# Altium schematic sheet PWR_Manage.SchDoc
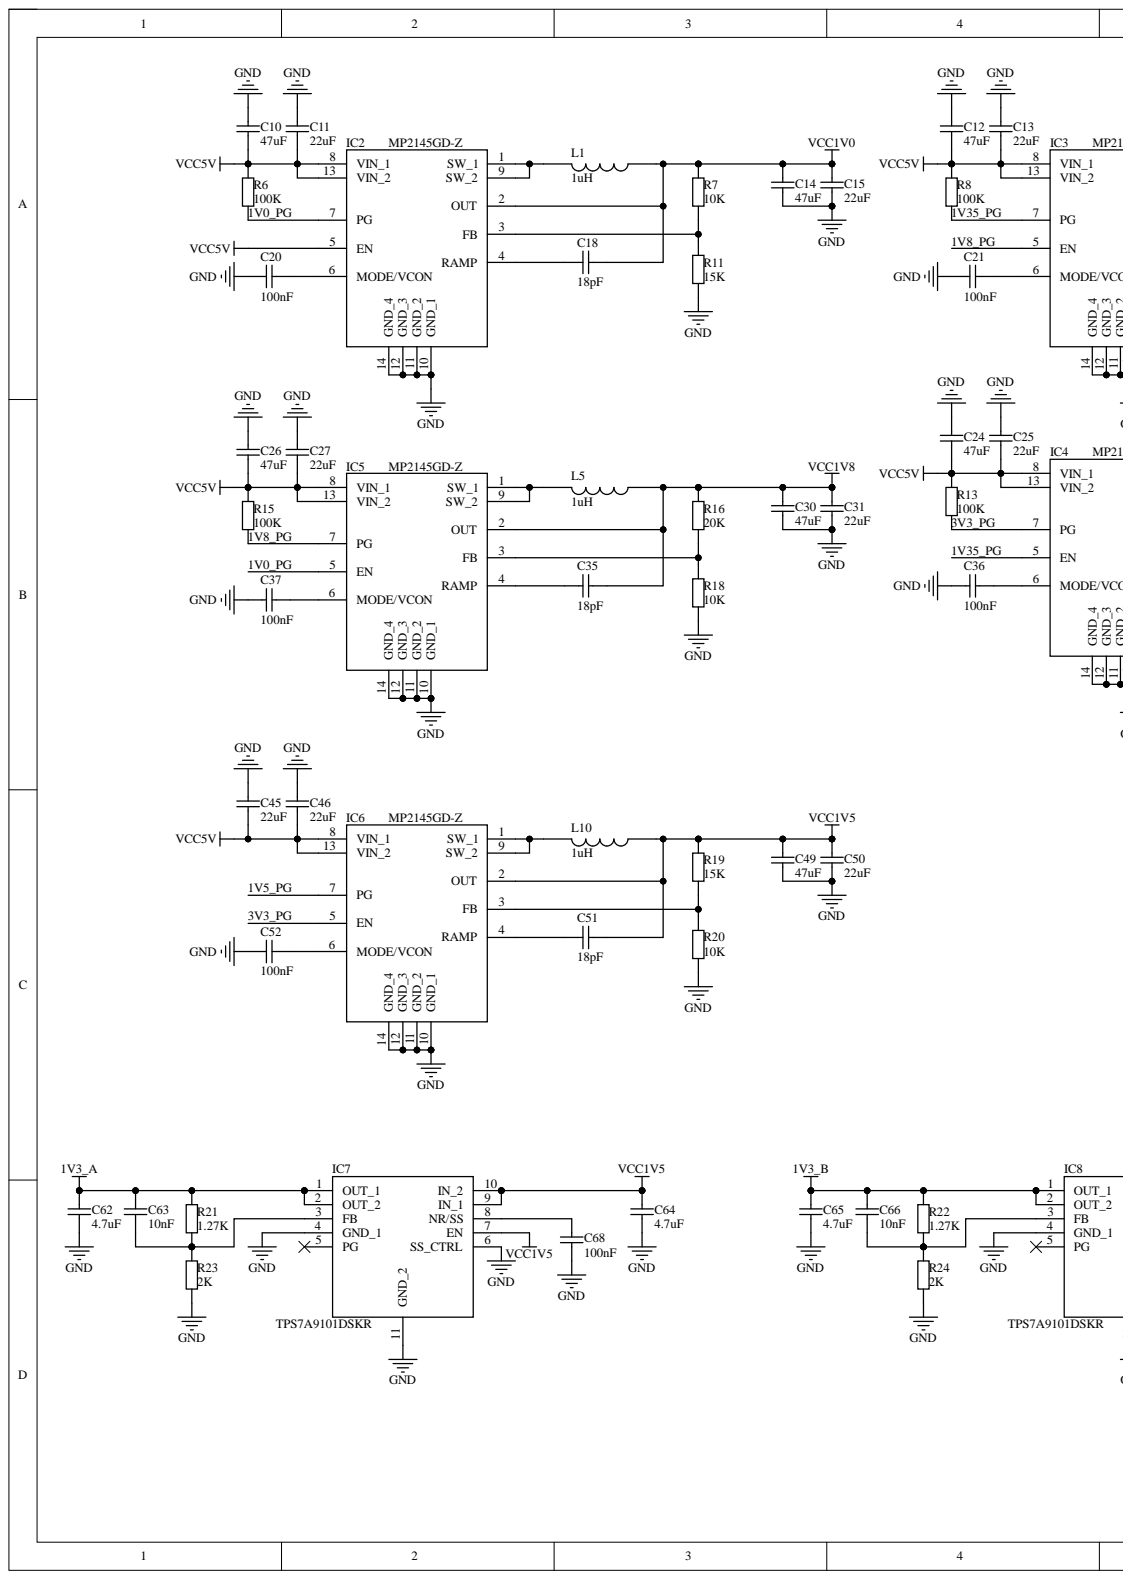
[diagram p0: PWR_Manage.SchDoc, left]
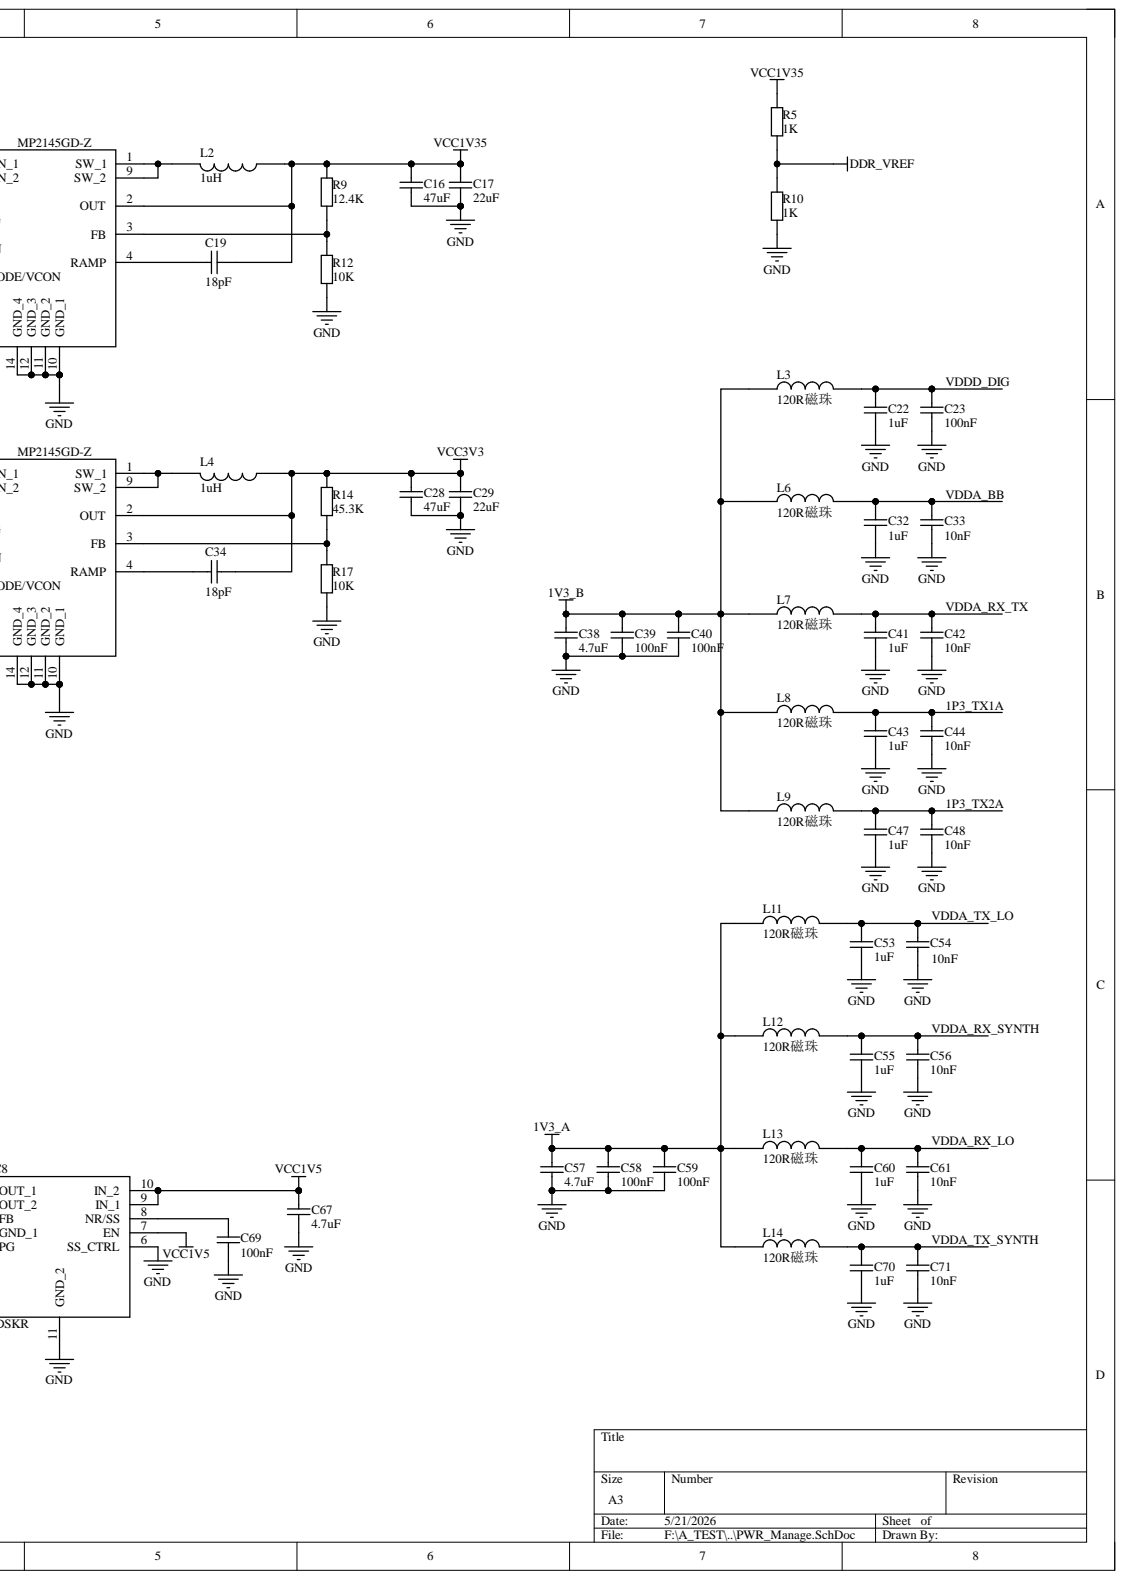
[diagram p1: PWR_Manage.SchDoc, right]

## Parts
C10  47uF  [C 0805_L]
C11  22uF  [C 0805_L]
C12  47uF  [C 0805_L]
C13  22uF  [C 0805_L]
C14  47uF  [C 0805_L]
C15  22uF  [C 0805_L]
C16  47uF  [C 0805_L]
C17  22uF  [C 0805_L]
C18  18pF  [C 0402_L]
C19  18pF  [C 0402_L]
C20  100nF  [C 0402_L]
C21  100nF  [C 0402_L]
C22  1uF  [C 0402_L]
C23  100nF  [C 0402_L]
C24  47uF  [C 0805_L]
C25  22uF  [C 0805_L]
C26  47uF  [C 0805_L]
C27  22uF  [C 0805_L]
C28  47uF  [C 0805_L]
C29  22uF  [C 0805_L]
C30  47uF  [C 0805_L]
C31  22uF  [C 0805_L]
C32  1uF  [C 0402_L]
C33  10nF  [C 0402_L]
C34  18pF  [C 0402_L]
C35  18pF  [C 0402_L]
C36  100nF  [C 0402_L]
C37  100nF  [C 0402_L]
C38  4.7uF  [C 0603_L]
C39  100nF  [C 0402_L]
C40  100nF  [C 0402_L]
C41  1uF  [C 0402_L]
C42  10nF  [C 0402_L]
C43  1uF  [C 0402_L]
C44  10nF  [C 0402_L]
C45  22uF  [C 0805_L]
C46  22uF  [C 0805_L]
C47  1uF  [C 0402_L]
C48  10nF  [C 0402_L]
C49  47uF  [C 0805_L]
C50  22uF  [C 0805_L]
C51  18pF  [C 0402_L]
C52  100nF  [C 0402_L]
C53  1uF  [C 0402_L]
C54  10nF  [C 0402_L]
C55  1uF  [C 0402_L]
C56  10nF  [C 0402_L]
C57  4.7uF  [C 0603_L]
C58  100nF  [C 0402_L]
C59  100nF  [C 0402_L]
C60  1uF  [C 0402_L]
C61  10nF  [C 0402_L]
C62  4.7uF  [C 0603_L]
C63  10nF  [C 0402_L]
C64  4.7uF  [C 0603_L]
C65  4.7uF  [C 0603_L]
C66  10nF  [C 0402_L]
C67  4.7uF  [C 0603_L]
C68  100nF  [C 0402_L]
C69  100nF  [C 0402_L]
C70  1uF  [C 0402_L]
C71  10nF  [C 0402_L]
IC2  MP2145GD-Z  [MP2145GDZ]
IC3  MP2145GD-Z  [MP2145GDZ]
IC4  MP2145GD-Z  [MP2145GDZ]
IC5  MP2145GD-Z  [MP2145GDZ]
IC6  MP2145GD-Z  [MP2145GDZ]
IC7  TPS7A9101DSKR  [SON50P250X250X80-11N-D]
IC8  TPS7A9101DSKR  [SON50P250X250X80-11N-D]
L1  1uH  [NR2520]
L2  1uH  [NR2520]
L3  120R磁珠  [L 0402]
L4  1uH  [NR2520]
L5  1uH  [NR2520]
L6  120R磁珠  [L 0402]
L7  120R磁珠  [L 0402]
L8  120R磁珠  [L 0402]
L9  120R磁珠  [L 0402]
L10  1uH  [NR2520]
L11  120R磁珠  [L 0402]
L12  120R磁珠  [L 0402]
L13  120R磁珠  [L 0402]
L14  120R磁珠  [L 0402]
R5  1K  [R 0402_L]
R6  100K  [R 0402_L]
R7  10K  [R 0402_L]
R8  100K  [R 0402_L]
R9  12.4K  [R 0402_L]
R10  1K  [R 0402_L]
R11  15K  [R 0402_L]
R12  10K  [R 0402_L]
R13  100K  [R 0402_L]
R14  45.3K  [R 0402_L]
R15  100K  [R 0402_L]
R16  20K  [R 0402_L]
R17  10K  [R 0402_L]
R18  10K  [R 0402_L]
R19  15K  [R 0402_L]
R20  10K  [R 0402_L]
R21  1.27K  [R 0402_L]
R22  1.27K  [R 0402_L]
R23  2K  [R 0402_L]
R24  2K  [R 0402_L]

## Nets
1V3_A: C57.2 C58.2 C59.2 C62.2 C63.2 IC7.1(OUT_1) IC7.2(OUT_2) L11.1 L12.1 L13.1 L14.1 R21.2
1V3_B: C38.2 C39.2 C40.2 C65.2 C66.2 IC8.1(OUT_1) IC8.2(OUT_2) L3.1 L6.1 L7.1 L8.1 L9.1 R22.2
DDR_VREF: R5.1 R10.2
GND: C10.2 C11.2 C12.2 C13.2 C14.2 C15.2 C16.2 C17.2 C20.1 C21.1 C22.1 C23.1 C24.2 C25.2 C26.2 C27.2 C28.2 C29.2 C30.2 C31.2 C32.1 C33.1 C36.1 C37.1 C38.1 C39.1 C40.1 C41.1 C42.1 C43.1 C44.1 C45.2 C46.2 C47.1 C48.1 C49.2 C50.2 C52.1 C53.1 C54.1 C55.1 C56.1 C57.1 C58.1 C59.1 C60.1 C61.1 C62.1 C64.1 C65.1 C67.1 C68.1 C69.1 C70.1 C71.1 IC2.10(GND_1) IC2.11(GND_2) IC2.12(GND_3) IC2.14(GND_4) IC3.10(GND_1) IC3.11(GND_2) IC3.12(GND_3) IC3.14(GND_4) IC4.10(GND_1) IC4.11(GND_2) IC4.12(GND_3) IC4.14(GND_4) IC5.10(GND_1) IC5.11(GND_2) IC5.12(GND_3) IC5.14(GND_4) IC6.10(GND_1) IC6.11(GND_2) IC6.12(GND_3) IC6.14(GND_4) IC7.4(GND_1) IC7.6(SS_CTRL) IC7.11(GND_2) IC8.4(GND_1) IC8.6(SS_CTRL) IC8.11(GND_2) R10.1 R11.2 R12.2 R17.2 R18.2 R20.2 R23.1 R24.1
VCC1V0: C14.1 C15.1 C18.2 IC2.2(OUT) L1.1 R7.2
VCC1V5: C49.1 C50.1 C51.2 C64.2 C67.2 IC6.2(OUT) IC7.7(EN) IC7.9(IN_1) IC7.10(IN_2) IC8.7(EN) IC8.9(IN_1) IC8.10(IN_2) L10.1 R19.2
VCC1V8: C30.1 C31.1 C35.2 IC5.2(OUT) L5.1 R16.2
VCC1V35: C16.1 C17.1 C19.2 IC3.2(OUT) L2.1 R5.2 R9.2
VCC3V3: C28.1 C29.1 C34.2 IC4.2(OUT) L4.1 R14.2
VCC5V: C10.1 C11.1 C12.1 C13.1 C24.1 C25.1 C26.1 C27.1 C45.1 C46.1 IC2.5(EN) IC2.8(VIN_1) IC2.13(VIN_2) IC3.8(VIN_1) IC3.13(VIN_2) IC4.8(VIN_1) IC4.13(VIN_2) IC5.8(VIN_1) IC5.13(VIN_2) IC6.8(VIN_1) IC6.13(VIN_2) R6.2 R8.2 R13.2 R15.2
1P3_TX1A: C43.2 C44.2 L8.2
1P3_TX2A: C47.2 C48.2 L9.2
1V0_PG: IC2.7(PG) IC5.5(EN) R6.1
1V5_PG: IC6.7(PG)
1V8_PG: IC3.5(EN) IC5.7(PG) R15.1
1V35_PG: IC3.7(PG) IC4.5(EN) R8.1
3V3_PG: IC4.7(PG) IC6.5(EN) R13.1
VDDA_BB: C32.2 C33.2 L6.2
VDDA_RX_LO: C60.2 C61.2 L13.2
VDDA_RX_SYNTH: C55.2 C56.2 L12.2
VDDA_RX_TX: C41.2 C42.2 L7.2
VDDA_TX_LO: C53.2 C54.2 L11.2
VDDA_TX_SYNTH: C70.2 C71.2 L14.2
VDDD_DIG: C22.2 C23.2 L3.2
NetC18_1: C18.1 IC2.4(RAMP)
NetC19_1: C19.1 IC3.4(RAMP)
NetC20_2: C20.2 IC2.6(MODE/VCON)
NetC21_2: C21.2 IC3.6(MODE/VCON)
NetC34_1: C34.1 IC4.4(RAMP)
NetC35_1: C35.1 IC5.4(RAMP)
NetC36_2: C36.2 IC4.6(MODE/VCON)
NetC37_2: C37.2 IC5.6(MODE/VCON)
NetC51_1: C51.1 IC6.4(RAMP)
NetC52_2: C52.2 IC6.6(MODE/VCON)
NetC63_1: C63.1 IC7.3(FB) R21.1 R23.2
NetC66_1: C66.1 IC8.3(FB) R22.1 R24.2
NetC68_2: C68.2 IC7.8(NR/SS)
NetC69_2: C69.2 IC8.8(NR/SS)
NetIC2_1: IC2.1(SW_1) IC2.9(SW_2) L1.2
NetIC2_3: IC2.3(FB) R7.1 R11.1
NetIC3_1: IC3.1(SW_1) IC3.9(SW_2) L2.2
NetIC3_3: IC3.3(FB) R9.1 R12.1
NetIC4_1: IC4.1(SW_1) IC4.9(SW_2) L4.2
NetIC4_3: IC4.3(FB) R14.1 R17.1
NetIC5_1: IC5.1(SW_1) IC5.9(SW_2) L5.2
NetIC5_3: IC5.3(FB) R16.1 R18.1
NetIC6_1: IC6.1(SW_1) IC6.9(SW_2) L10.2
NetIC6_3: IC6.3(FB) R19.1 R20.1

Not connected: IC7 5(PG); IC8 5(PG)

## Library data of the parts
C10-C71 = Cap: 贴片电容; Component Kind: Standard; Library Name: A_Schlib.SchLib
  C10 C12 C14 C16 C24 C26 C28 C30 C49: value: 47uF
  C11 C13 C15 C17 C25 C27 C29 C31 C45 C46 C50: value: 22uF
  C18 C19 C34 C35 C51: value: 18pF
  C20 C21 C23 C36 C37 C39 C40 C52 C58 C59 C68 C69: value: 100nF
  C22 C32 C41 C43 C47 C53 C55 C60 C70: value: 1uF
  C33 C42 C44 C48 C54 C56 C61 C63 C66 C71: value: 10nF
  C38 C57 C62 C64 C65 C67: value: 4.7uF
IC2-IC6 = MP2145GD-Z: Integrated Circuit; Manufacturer_Name: Monolithic Power Systems (MPS); Component Kind: Standard; Datasheet Link: https://www.monolithicpower.com/en/documentview/productdocument/index/version/2/document_type/Datasheet/lang/en/sku/MP2145/document_id/832/; Height: 1mm; Library Name: SamacSys.SchLib; Manufacturer_Part_Number: MP2145GD-Z; Mouser Part Number: 946-MP2145GD-Z; Mouser Price/Stock: https://www.mouser.co.uk/ProductDetail/Monolithic-Power-Systems-MPS/MP2145GD-Z?qs=AfGmtpoX2giMfxnoRRoQSw%3D%3D
IC7 IC8 = TPS7A9101DSKR: Integrated Circuit; Manufacturer_Name: Texas Instruments; Arrow Part Number: TPS7A9101DSKR; Arrow Price/Stock: https://www.arrow.com/en/products/tps7a9101dskr/texas-instruments?region=nac; Component Kind: Standard; Datasheet Link: http://www.ti.com/lit/ds/symlink/tps7a91.pdf; Height: 0.8mm; Manufacturer_Part_Number: TPS7A9101DSKR; Mouser Part Number: 595-TPS7A9101DSKR; Mouser Price/Stock: https://www.mouser.co.uk/ProductDetail/Texas-Instruments/TPS7A9101DSKR?qs=34RfhUjJmKdov7HQNWp7rg%3D%3D
L1-L14 = 电感: 包含功率; Component Kind: Standard; Library Name: A_Schlib.SchLib
R5 R10 = Res: Component Kind: Standard; value: 1K; Library Name: 零散元件库.SCHLIB
R6-R9 R11-R24 = Res: 通用; Component Kind: Standard; Library Name: A_Schlib.SchLib
  R6 R8 R13 R15: value: 100K
  R7 R12 R17 R18 R20: value: 10K
  R9: value: 12.4K
  R11 R19: value: 15K
  R14: value: 45.3K
  R16: value: 20K
  R21 R22: value: 1.27K
  R23 R24: value: 2K
